# Altium schematic sheet sense1.SchDoc
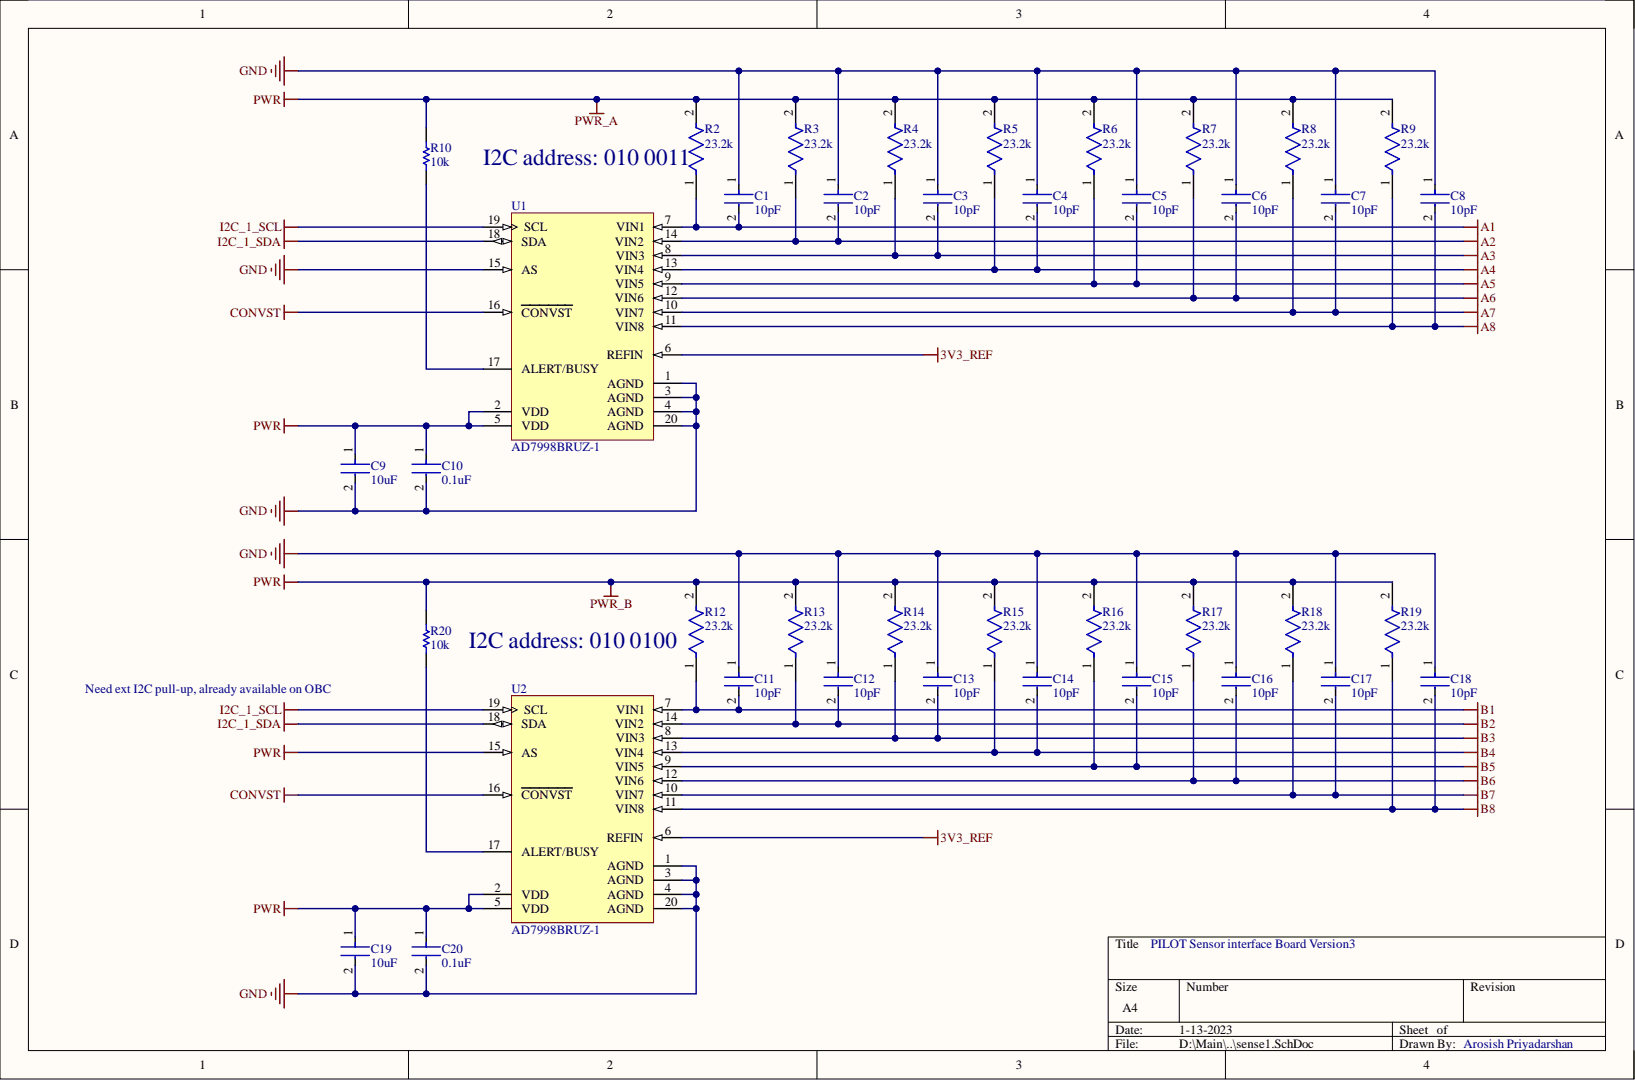
[diagram p0: sense1.SchDoc]

## Parts
C1  10pF  [FP-C0603C-CF-MFG]
C2  10pF  [FP-C0603C-CF-MFG]
C3  10pF  [FP-C0603C-CF-MFG]
C4  10pF  [FP-C0603C-CF-MFG]
C5  10pF  [FP-C0603C-CF-MFG]
C6  10pF  [FP-C0603C-CF-MFG]
C7  10pF  [FP-C0603C-CF-MFG]
C8  10pF  [FP-C0603C-CF-MFG]
C9  10uF  [FP-GCM21B-0_2-e0_2_0_7-IPC_C]
C10  0.1uF  [FP-C0603C104K5RECAUTO-MFG]
C11  10pF  [FP-C0603C-CF-MFG]
C12  10pF  [FP-C0603C-CF-MFG]
C13  10pF  [FP-C0603C-CF-MFG]
C14  10pF  [FP-C0603C-CF-MFG]
C15  10pF  [FP-C0603C-CF-MFG]
C16  10pF  [FP-C0603C-CF-MFG]
C17  10pF  [FP-C0603C-CF-MFG]
C18  10pF  [FP-C0603C-CF-MFG]
C19  10uF  [FP-GCM21B-0_2-e0_2_0_7-IPC_C]
C20  0.1uF  [FP-C0603C104K5RECAUTO-MFG]
R2  23.2k  [FP-RT0805-MFG]
R3  23.2k  [FP-RT0805-MFG]
R4  23.2k  [FP-RT0805-MFG]
R5  23.2k  [FP-RT0805-MFG]
R6  23.2k  [FP-RT0805-MFG]
R7  23.2k  [FP-RT0805-MFG]
R8  23.2k  [FP-RT0805-MFG]
R9  23.2k  [FP-RT0805-MFG]
R10  10k  [RESC2013X55X40ML15T20]
R12  23.2k  [FP-RT0805-MFG]
R13  23.2k  [FP-RT0805-MFG]
R14  23.2k  [FP-RT0805-MFG]
R15  23.2k  [FP-RT0805-MFG]
R16  23.2k  [FP-RT0805-MFG]
R17  23.2k  [FP-RT0805-MFG]
R18  23.2k  [FP-RT0805-MFG]
R19  23.2k  [FP-RT0805-MFG]
R20  10k  [RESC2013X55X40ML15T20]
U1  AD7998BRUZ-1  [RU-20_N]
U2  AD7998BRUZ-1  [RU-20_N]

## Nets
3V3_REF: U1.6(REFIN) U2.6(REFIN)
A1: C1.2 R2.1 U1.7(VIN1)
A2: C2.2 R3.1 U1.14(VIN2)
A3: C3.2 R4.1 U1.8(VIN3)
A4: C4.2 R5.1 U1.13(VIN4)
A5: C5.2 R6.1 U1.9(VIN5)
A6: C6.2 R7.1 U1.12(VIN6)
A7: C7.2 R8.1 U1.10(VIN7)
A8: C8.2 R9.1 U1.11(VIN8)
B1: C11.2 R12.1 U2.7(VIN1)
B2: C12.2 R13.1 U2.14(VIN2)
B3: C13.2 R14.1 U2.8(VIN3)
B4: C14.2 R15.1 U2.13(VIN4)
B5: C15.2 R16.1 U2.9(VIN5)
B6: C16.2 R17.1 U2.12(VIN6)
B7: C17.2 R18.1 U2.10(VIN7)
B8: C18.2 R19.1 U2.11(VIN8)
CONVST: U1.16(CONVST) U2.16(CONVST)
GND: C1.1 C2.1 C3.1 C4.1 C5.1 C6.1 C7.1 C8.1 C9.2 C10.2 C11.1 C12.1 C13.1 C14.1 C15.1 C16.1 C17.1 C18.1 C19.2 C20.2 U1.1(AGND) U1.3(AGND) U1.4(AGND) U1.15(AS) U1.20(AGND) U2.1(AGND) U2.3(AGND) U2.4(AGND) U2.20(AGND)
I2C_1_SCL: U1.19(SCL) U2.19(SCL)
I2C_1_SDA: U1.18(SDA) U2.18(SDA)
PWR: C9.1 C10.1 C19.1 C20.1 R2.2 R3.2 R4.2 R5.2 R6.2 R7.2 R8.2 R9.2 R10.2 R12.2 R13.2 R14.2 R15.2 R16.2 R17.2 R18.2 R19.2 R20.2 U1.2(VDD) U1.5(VDD) U2.2(VDD) U2.5(VDD) U2.15(AS)
NetR10_1: R10.1 U1.17(ALERT/BUSY)
NetR20_1: R20.1 U2.17(ALERT/BUSY)

## Library data of the parts
C1-C8 C11-C18 = CMP-03020-008759-1: C0603 10 pF C0G 30ppm/°C 5.00% 25 V; Manufacturer: KEMET; Manufacturer Part Number: C0603C100J3GACAUTO; Automotive Grade: Grade 1; Material: Ceramic; Tolerance: 0.05; Voltage Rating: 25 V; Automotive: Yes; Capacitance: 10 pF; Category: Cap; Component Kind: Standard; ComponentLink2Description: Datasheet; ComponentLink2URL: https://api.kemet.com/component-edge/download/datasheet/C0603C100J5GACAUTO.pdf; Device Class L1: Passive Components; Device Class L2: Capacitors; Device Class L3: Ceramic Capacitors; Height: 0.87mm; Lead Free: Yes; Library Name: Altium Content Vault; Octopart: https://octopart.com/c0603c100j3gacauto-kemet-72007099; Package: C0603C-CF; RoHS: TRUE; Temperature: -55°C to +125°C; Temperature Characteristic: C0G; Temperature Coefficient: 30ppm/°C; Temperature Range High: +125°C; Temperature Range Low: -55°C; Value: 10 pF
C9 C19 = CMP-06035-029187-1: Ceramic Capacitor for Automotive 10uF ±10% 16VDC X7S 0805 Embossed T/R; Component Kind: Standard; Library Name: Altium Content Vault
C10 C20 = CMP-03020-034675-1: CAP CER 0.10UF 50V X7R 0603; Capacitance: 100nF; Interface: SPI; Number of A/D Converters: 1; Number of Bits: 16; Sampling Rate: 4000S/s; Tolerance: 10%; Case Code (Imperial): 0603; Case Code (Metric): 1608; Case/Package: 0603; Component Kind: Standard; Library Name: Altium Content Vault; Max Operating Temperature: 125°C; Min Operating Temperature: -55°C; Mount: Surface Mount; Packaging: Tape and Reel; Ratings: AEC-Q200; RoHS Compliant: Yes; Voltage Rating: 50V
R2-R9 R12-R19 = CMP-03412-003095-1: RES SMD 23.2K OHM 0.1% 1/8W 0805; Manufacturer: Yageo; Manufacturer Part Number: RT0805BRD0723K2L; Power Rating: 0.125W; Resistance: 23.2KΩ; Temperature Coefficient: 25 ppm/°C; Tolerance: 0.0025; Value: 23.2KΩ; Voltage Rating: 150V; Automotive: No; Category: Res; Component Kind: Standard; ComponentLink2Description: Datasheet; ComponentLink2URL: http://www.yageo.com/documents/recent/PYu-RT_1-to-0.01_RoHS_L_9.pdf; Composition: Thin Film; Device Class L1: Passive Components; Device Class L2: Resistors; Device Class L3: Chip SMD Resistors; Digikey Description: RES SMD 23.2K OHM 0.1% 1/8W 0805; Footprint Url: http://www.yageo.com/exep/pages/download/literatures/PYu-R_Mount_10.pdf; Height: 0.6mm; Ipc Land Pattern Name: RESC200125X50; Lead Free: Yes; Library Name: Altium Content Vault; Mouser Description: Thin Film Resistors - SMD 1/8W 23.2K ohm .1% 25ppm; Octopart: https://octopart.com/rt0805brd0723k2l-yageo-1375179; Package: RT0805; RoHS: TRUE; Series: RT; Temperature: -55°C to +155°C; Temperature Range High: +155°C; Temperature Range Low: -55°C
R10 R20 = CMP-2001-04724-1: Manufacturer: Vishay Dale; Part Number: TNPW080510K0BEEA; Component Kind: Standard; Library Name: Altium Content Vault
U1 U2 = CMP-0313-01824-2: Octal 12-Bit Analog-to-Digital Converter with I2C / Serial 2-Wire, Serialial Interface, 79KSPS, -40 to +85 degC, RU-20, Pb-Free, Tube; Generic Part Number: AD7998; Manufacturer: Analog Devices; Channels: 8; Interface: I2C/Ser 2-Wire, Ser; Resolution (Bits): 12; Throughput Rate (SPS): 79K; Code_JEDEC: MO-153AC; Component Kind: Standard; ComponentLink1Description: Manufacturer URL; ComponentLink1URL: http://www.analog.com/en/index.html; ComponentLink2Description: Datasheet; ComponentLink2URL: http://www.analog.com/static/imported-files/data_sheets/AD7997_7998.pdf; ComponentLink3Description: Package Specification; ComponentLink3URL: http://www.analog.com/static/imported-files/packages/364515793657813558433715854054607941441RU20.pdf; ComponentLink4Description: Product URL; ComponentLink4URL: http://www.analog.com/en/analog-to-digital-converters/ad-converters/ad7998/products/product.html; DatasheetVersion: Rev.0; Library Name: Altium Content Vault; Mounting Technology: Surface Mount; PackageDescription: 20-Lead Thin Shrink Small Outline Package [TSSOP]; PackageReference: RU-20; PackageVersion: 2000; Packing: Tube; PartNumber: AD7998BRUZ-1; RoHS: Y
